# Altium schematic sheet 电原理图.SchDoc
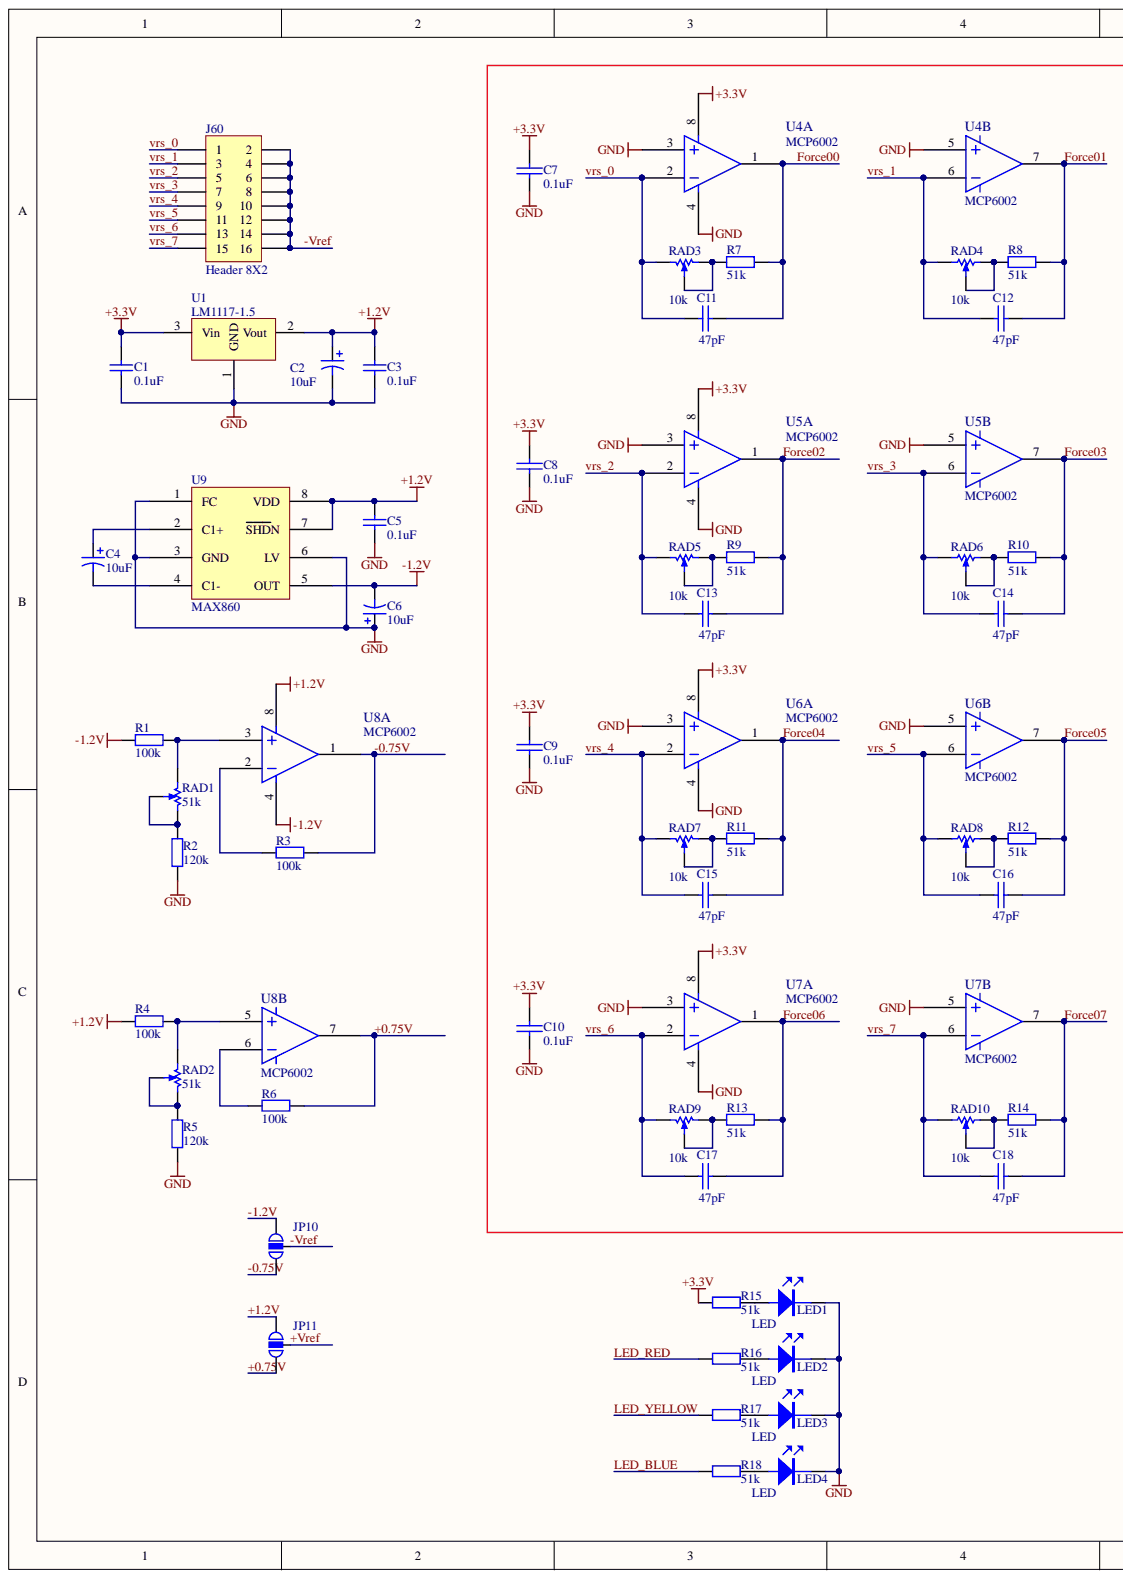
[diagram p0: 电原理图.SchDoc, left]
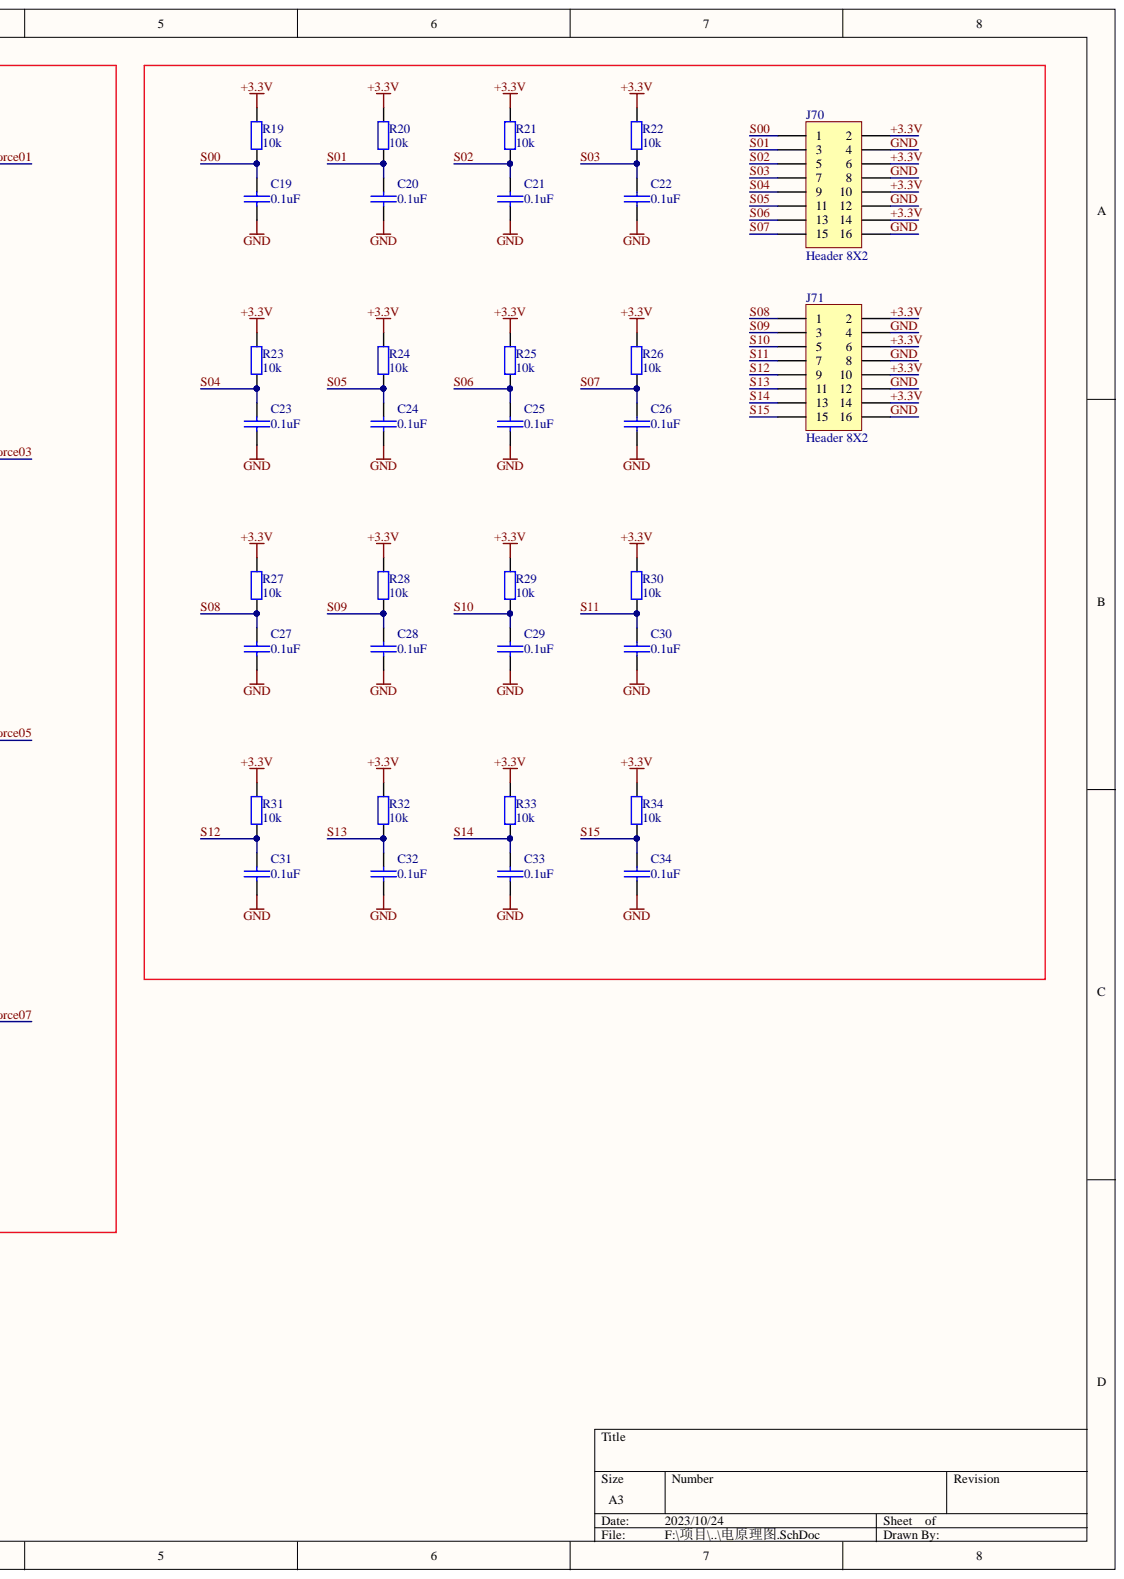
[diagram p1: 电原理图.SchDoc, right]

## Parts
C1  value 0.1uF  [CC0805]  (hidden comment: 0805-50V-0.1uF)
C2  value 10uF  [SMD-EC-F5]  (hidden comment: CD-50V-10uF-F5(SMD))
C3  value 0.1uF  [CC0805]  (hidden comment: 0805-50V-0.1uF)
C4  value 10uF  [SMD-EC-F5]  (hidden comment: CD-50V-10uF-F5(SMD))
C5  value 0.1uF  [CC0805]  (hidden comment: 0805-50V-0.1uF)
C6  value 10uF  [SMD-EC-F5]  (hidden comment: CD-50V-10uF-F5(SMD))
C7  value 0.1uF  [CC0805]  (hidden comment: 0805-50V-0.1uF)
C8  value 0.1uF  [CC0805]  (hidden comment: 0805-50V-0.1uF)
C9  value 0.1uF  [CC0805]  (hidden comment: 0805-50V-0.1uF)
C10  value 0.1uF  [CC0805]  (hidden comment: 0805-50V-0.1uF)
C11  value 47pF  [CC0805]  (hidden comment: 0805-50V-47pF)
C12  value 47pF  [CC0805]  (hidden comment: 0805-50V-47pF)
C13  value 47pF  [CC0805]  (hidden comment: 0805-50V-47pF)
C14  value 47pF  [CC0805]  (hidden comment: 0805-50V-47pF)
C15  value 47pF  [CC0805]  (hidden comment: 0805-50V-47pF)
C16  value 47pF  [CC0805]  (hidden comment: 0805-50V-47pF)
C17  value 47pF  [CC0805]  (hidden comment: 0805-50V-47pF)
C18  value 47pF  [CC0805]  (hidden comment: 0805-50V-47pF)
C19  value 0.1uF  [CC0805]  (hidden comment: 0805-50V-0.1uF)
C20  value 0.1uF  [CC0805]  (hidden comment: 0805-50V-0.1uF)
C21  value 0.1uF  [CC0805]  (hidden comment: 0805-50V-0.1uF)
C22  value 0.1uF  [CC0805]  (hidden comment: 0805-50V-0.1uF)
C23  value 0.1uF  [CC0805]  (hidden comment: 0805-50V-0.1uF)
C24  value 0.1uF  [CC0805]  (hidden comment: 0805-50V-0.1uF)
C25  value 0.1uF  [CC0805]  (hidden comment: 0805-50V-0.1uF)
C26  value 0.1uF  [CC0805]  (hidden comment: 0805-50V-0.1uF)
C27  value 0.1uF  [CC0805]  (hidden comment: 0805-50V-0.1uF)
C28  value 0.1uF  [CC0805]  (hidden comment: 0805-50V-0.1uF)
C29  value 0.1uF  [CC0805]  (hidden comment: 0805-50V-0.1uF)
C30  value 0.1uF  [CC0805]  (hidden comment: 0805-50V-0.1uF)
C31  value 0.1uF  [CC0805]  (hidden comment: 0805-50V-0.1uF)
C32  value 0.1uF  [CC0805]  (hidden comment: 0805-50V-0.1uF)
C33  value 0.1uF  [CC0805]  (hidden comment: 0805-50V-0.1uF)
C34  value 0.1uF  [CC0805]  (hidden comment: 0805-50V-0.1uF)
J60  Header 8X2  [HDR2X8]
J70  Header 8X2  [HDR2X8]
J71  Header 8X2  [HDR2X8]
JP10  Jumper  [PIN2]  (value not drawn)
JP11  Jumper  [PIN2]  (value not drawn)
LED1  value LED  [LED-R3]  (hidden comment: LED-φ3(翠绿))
LED2  value LED  [LED-R3]  (hidden comment: LED-φ3(翠绿))
LED3  value LED  [LED-R3]  (hidden comment: LED-φ3(翠绿))
LED4  value LED  [LED-R3]  (hidden comment: LED-φ3(翠绿))
R1  value 100k  [0805]  (hidden comment: 0805-100kΩ±1％)
R2  value 120k  [0805]  (hidden comment: 0805-120kΩ±1％)
R3  value 100k  [0805]  (hidden comment: 0805-100kΩ±1％)
R4  value 100k  [0805]  (hidden comment: 0805-100kΩ±1％)
R5  value 120k  [0805]  (hidden comment: 0805-120kΩ±1％)
R6  value 100k  [0805]  (hidden comment: 0805-100kΩ±1％)
R7  value 51k  [0805]  (hidden comment: 0805-51kΩ±1％)
R8  value 51k  [0805]  (hidden comment: 0805-51kΩ±1％)
R9  value 51k  [0805]  (hidden comment: 0805-51kΩ±1％)
R10  value 51k  [0805]  (hidden comment: 0805-51kΩ±1％)
R11  value 51k  [0805]  (hidden comment: 0805-51kΩ±1％)
R12  value 51k  [0805]  (hidden comment: 0805-51kΩ±1％)
R13  value 51k  [0805]  (hidden comment: 0805-51kΩ±1％)
R14  value 51k  [0805]  (hidden comment: 0805-51kΩ±1％)
R15  value 51k  [0805]  (hidden comment: 0805-51kΩ±1％)
R16  value 51k  [0805]  (hidden comment: 0805-51kΩ±1％)
R17  value 51k  [0805]  (hidden comment: 0805-51kΩ±1％)
R18  value 51k  [0805]  (hidden comment: 0805-51kΩ±1％)
R19  value 10k  [0805]  (hidden comment: 0805-10kΩ±1％)
R20  value 10k  [0805]  (hidden comment: 0805-10kΩ±1％)
R21  value 10k  [0805]  (hidden comment: 0805-10kΩ±1％)
R22  value 10k  [0805]  (hidden comment: 0805-10kΩ±1％)
R23  value 10k  [0805]  (hidden comment: 0805-10kΩ±1％)
R24  value 10k  [0805]  (hidden comment: 0805-10kΩ±1％)
R25  value 10k  [0805]  (hidden comment: 0805-10kΩ±1％)
R26  value 10k  [0805]  (hidden comment: 0805-10kΩ±1％)
R27  value 10k  [0805]  (hidden comment: 0805-10kΩ±1％)
R28  value 10k  [0805]  (hidden comment: 0805-10kΩ±1％)
R29  value 10k  [0805]  (hidden comment: 0805-10kΩ±1％)
R30  value 10k  [0805]  (hidden comment: 0805-10kΩ±1％)
R31  value 10k  [0805]  (hidden comment: 0805-10kΩ±1％)
R32  value 10k  [0805]  (hidden comment: 0805-10kΩ±1％)
R33  value 10k  [0805]  (hidden comment: 0805-10kΩ±1％)
R34  value 10k  [0805]  (hidden comment: 0805-10kΩ±1％)
RAD1  value 51k  [3224W]  (hidden comment: 3224W-51k)
RAD2  value 51k  [3224W]  (hidden comment: 3224W-51k)
RAD3  value 10k  [3224W]  (hidden comment: 3224W-10k)
RAD4  value 10k  [3224W]  (hidden comment: 3224W-10k)
RAD5  value 10k  [3224W]  (hidden comment: 3224W-10k)
RAD6  value 10k  [3224W]  (hidden comment: 3224W-10k)
RAD7  value 10k  [3224W]  (hidden comment: 3224W-10k)
RAD8  value 10k  [3224W]  (hidden comment: 3224W-10k)
RAD9  value 10k  [3224W]  (hidden comment: 3224W-10k)
RAD10  value 10k  [3224W]  (hidden comment: 3224W-10k)
U1  LM1117-1.5  [SOT223]
U4  MCP6002  [SO-8]  (parts A,B)
U5  MCP6002  [SO-8]  (parts A,B)
U6  MCP6002  [SO-8]  (parts A,B)
U7  MCP6002  [SO-8]  (parts A,B)
U8  MCP6002  [SO-8]  (parts A,B)
U9  value MAX860  [SO-8]  (hidden comment: max660)

## Nets
+0.75V: JP11.3 R6.2 U8.7
+1.2V: C2.1 C3.2 C5.2 JP11.1 R4.2 U1.2 U8.8 U9.7(SHDN) U9.8(VDD)
+3.3V: C1.2 C7.2 C8.2 C9.2 C10.2 J70.2 J70.6 J70.10 J70.14 J71.2 J71.6 J71.10 J71.14 R15.2 R19.1 R20.1 R21.1 R22.1 R23.1 R24.1 R25.1 R26.1 R27.1 R28.1 R29.1 R30.1 R31.1 R32.1 R33.1 R34.1 U1.3 U4.8 U5.8 U6.8 U7.8
+VREF: JP11.2
-0.75V: JP10.3 R3.2 U8.1
-1.2V: C6.2 JP10.1 R1.2 U8.4 U9.5(OUT)
-VREF: J60.2 J60.4 J60.6 J60.8 J60.10 J60.12 J60.14 J60.16 JP10.2
FORCE00: C11.1 R7.2 U4.1
FORCE01: C12.1 R8.1 U4.7
FORCE02: C13.1 R9.2 U5.1
FORCE03: C14.1 R10.1 U5.7
FORCE04: C15.1 R11.2 U6.1
FORCE05: C16.1 R12.1 U6.7
FORCE06: C17.1 R13.2 U7.1
FORCE07: C18.1 R14.1 U7.7
GND: C1.1 C2.2 C3.1 C5.1 C6.1 C7.1 C8.1 C9.1 C10.1 C19.2 C20.2 C21.2 C22.2 C23.2 C24.2 C25.2 C26.2 C27.2 C28.2 C29.2 C30.2 C31.2 C32.2 C33.2 C34.2 J70.4 J70.8 J70.12 J70.16 J71.4 J71.8 J71.12 J71.16 LED1.2 LED2.2 LED3.2 LED4.2 R2.1 R5.1 U1.1 U4.3 U4.4 U4.5 U5.3 U5.4 U5.5 U6.3 U6.4 U6.5 U7.3 U7.4 U7.5 U9.1(FC) U9.3(GND) U9.6(LV)
LED_BLUE: R18.2
LED_RED: R16.2
LED_YELLOW: R17.2
S00: C19.1 J70.1 R19.2
S01: C20.1 J70.3 R20.2
S02: C21.1 J70.5 R21.2
S03: C22.1 J70.7 R22.2
S04: C23.1 J70.9 R23.2
S05: C24.1 J70.11 R24.2
S06: C25.1 J70.13 R25.2
S07: C26.1 J70.15 R26.2
S08: C27.1 J71.1 R27.2
S09: C28.1 J71.3 R28.2
S10: C29.1 J71.5 R29.2
S11: C30.1 J71.7 R30.2
S12: C31.1 J71.9 R31.2
S13: C32.1 J71.11 R32.2
S14: C33.1 J71.13 R33.2
S15: C34.1 J71.15 R34.2
VRS_0: C11.2 J60.1 RAD3.3 U4.2
VRS_1: C12.2 J60.3 RAD4.1 U4.6
VRS_2: C13.2 J60.5 RAD5.3 U5.2
VRS_3: C14.2 J60.7 RAD6.1 U5.6
VRS_4: C15.2 J60.9 RAD7.3 U6.2
VRS_5: C16.2 J60.11 RAD8.1 U6.6
VRS_6: C17.2 J60.13 RAD9.3 U7.2
VRS_7: C18.2 J60.15 RAD10.1 U7.6
NetC4_1: C4.1 U9.2(C1+)
NetC4_2: C4.2 U9.4(C1-)
NetLED1_1: LED1.1 R15.1
NetLED2_1: LED2.1 R16.1
NetLED3_1: LED3.1 R17.1
NetLED4_1: LED4.1 R18.1
NetR1_1: R1.1 RAD1.3 U8.3
NetR2_2: R2.2 RAD1.1 RAD1.2
NetR3_1: R3.1 U8.2
NetR4_1: R4.1 RAD2.3 U8.5
NetR5_2: R5.2 RAD2.1 RAD2.2
NetR6_1: R6.1 U8.6
NetR7_1: R7.1 RAD3.1 RAD3.2
NetR8_2: R8.2 RAD4.2 RAD4.3
NetR9_1: R9.1 RAD5.1 RAD5.2
NetR10_2: R10.2 RAD6.2 RAD6.3
NetR11_1: R11.1 RAD7.1 RAD7.2
NetR12_2: R12.2 RAD8.2 RAD8.3
NetR13_1: R13.1 RAD9.1 RAD9.2
NetR14_2: R14.2 RAD10.2 RAD10.3

## Library data of the parts
C1 C3 C5 = Cap: 贴片电容; Component Kind: Standard; Library Name: Miscellaneous Devices.IntLib
C2 C4 C6 = Cap Pol1: 贴片铝电解电容; Component Kind: Standard; Library Name: Miscellaneous Devices.IntLib
C7-C34 = CAP: 贴片电容; Component Kind: Standard
J60 J70 J71 = Header 8X2: Header, 8-Pin, Dual row; Component Kind: Standard; LatestRevisionDate: 17-Jul-2002; LatestRevisionNote: Re-released for DXP Platform.; Library Name: Miscellaneous Connectors.IntLib; Signal Integrity: Connector; Publisher: Altium Limited
JP10 JP11 = Bell: Component Kind: Standard; Description: Jumper; LatestRevisionDate: 17-Jul-2002; LatestRevisionNote: Re-released for DXP Platform.; Library Name: SHIELD_3.SCHLIB; PackageReference: PIN2; Published: 24-Mar-1999; Publisher: Altium Limited
LED1-LED4 = LED: 发光二极管; Component Kind: Standard
R1-R14 R19-R34 = Res2: 贴片电阻; Component Kind: Standard; Library Name: Miscellaneous Devices.IntLib; Signal Integrity: Res; Simulation: RESISTOR
R15-R18 = Res2: 贴片电阻器; Component Kind: Standard; Library Name: Miscellaneous Devices.IntLib
RAD1-RAD10 = RPot SM: 贴片电位器; Component Kind: Standard; Library Name: Miscellaneous Devices.IntLib
U1 = 78: 三端稳压器; Component Kind: Standard; Value: LM1117-1.5
U4-U8 = 1458: 集成电路; Component Kind: Standard; Value: MCP6002
U9 = max860: 集成电路; Component Kind: Standard; Library Name: Schlib1.SchLib
